# Altium schematic sheet PWR.SchDoc
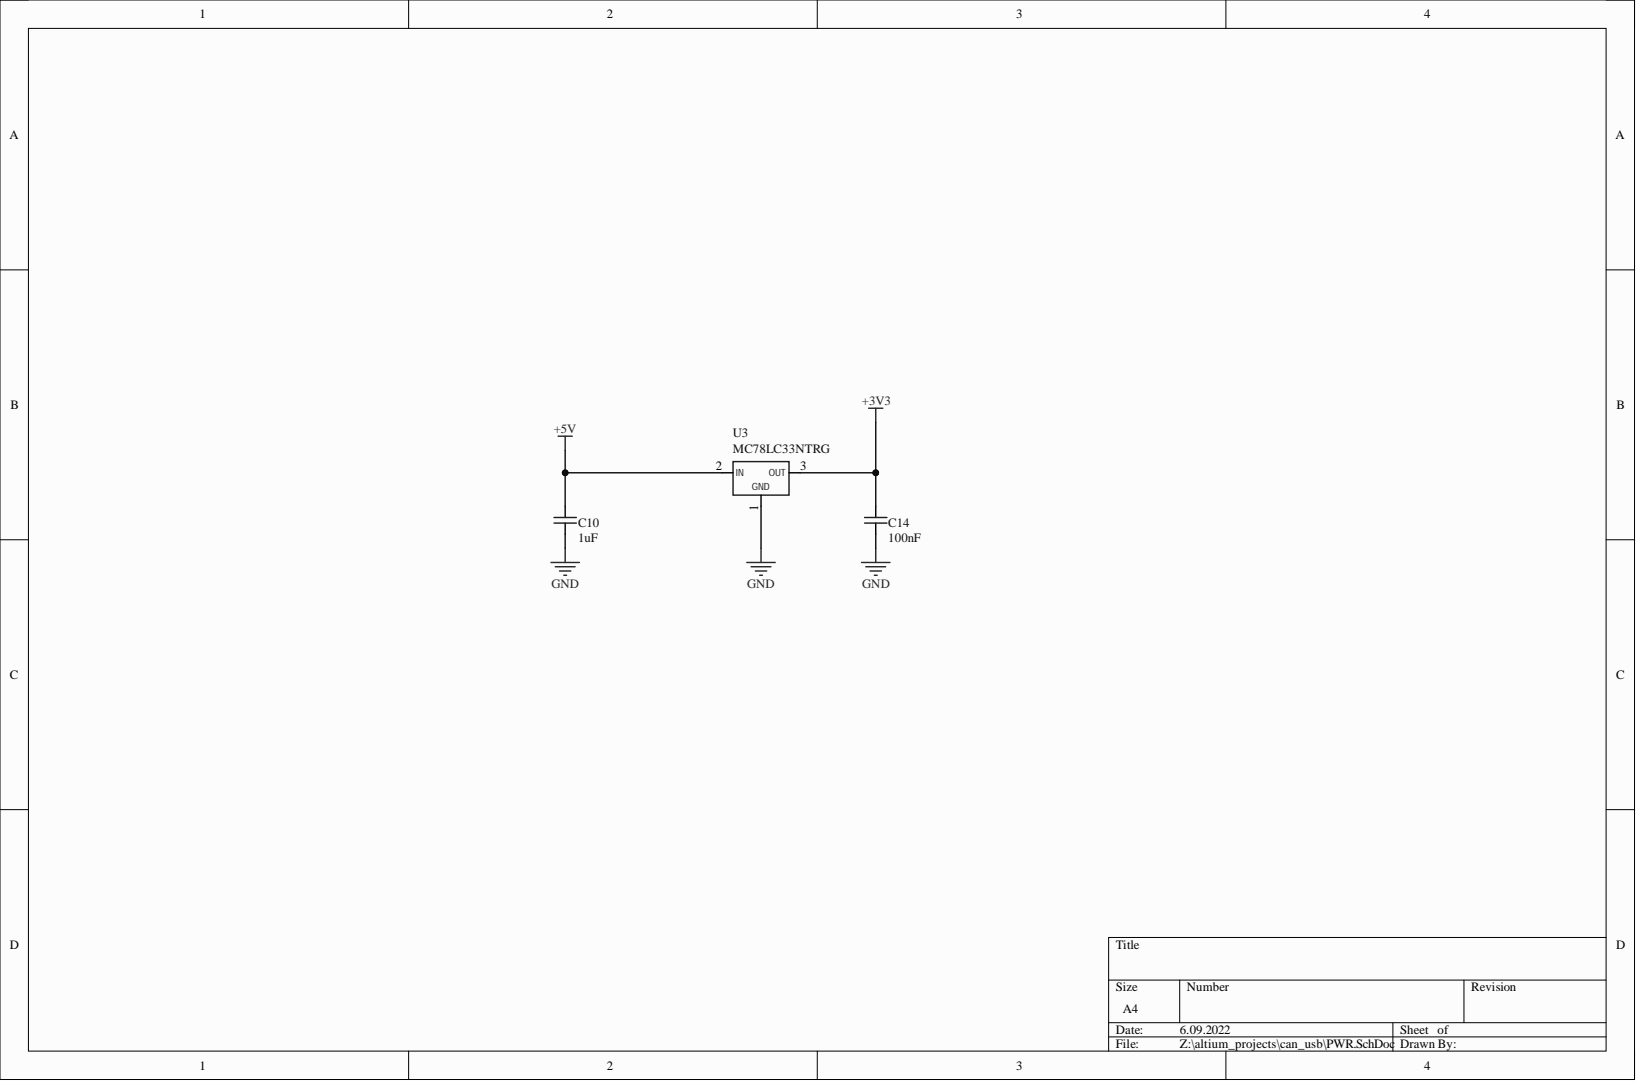
[diagram p0: PWR.SchDoc]

## Parts
C10  1uF  [SMD_0805]
C14  100nF  [SMD_0805]
U3  MC78LC33NTRG  [SOT753]

## Nets
+3V3: C14.1 U3.3
+5V: C10.1 U3.2
GND: C10.2 C14.2 U3.1

## Library data of the parts
C10 = C_NONE_POLAR: None polar capacitor; Component Kind: Standard; Library Name: DISCRETE.SchLib; Value: 1uF
C14 = C_NONE_POLAR: None polar capacitor; Value: 100n; Component Kind: Standard; Library Name: DISCRETE.SchLib
U3 = MC78LC33NTRG: Low dropout Linear Voltage Regulator; Component Kind: Standard; Library Name: ICs.SchLib
